# Altium schematic sheet Regulator.SchDoc(Regulator)
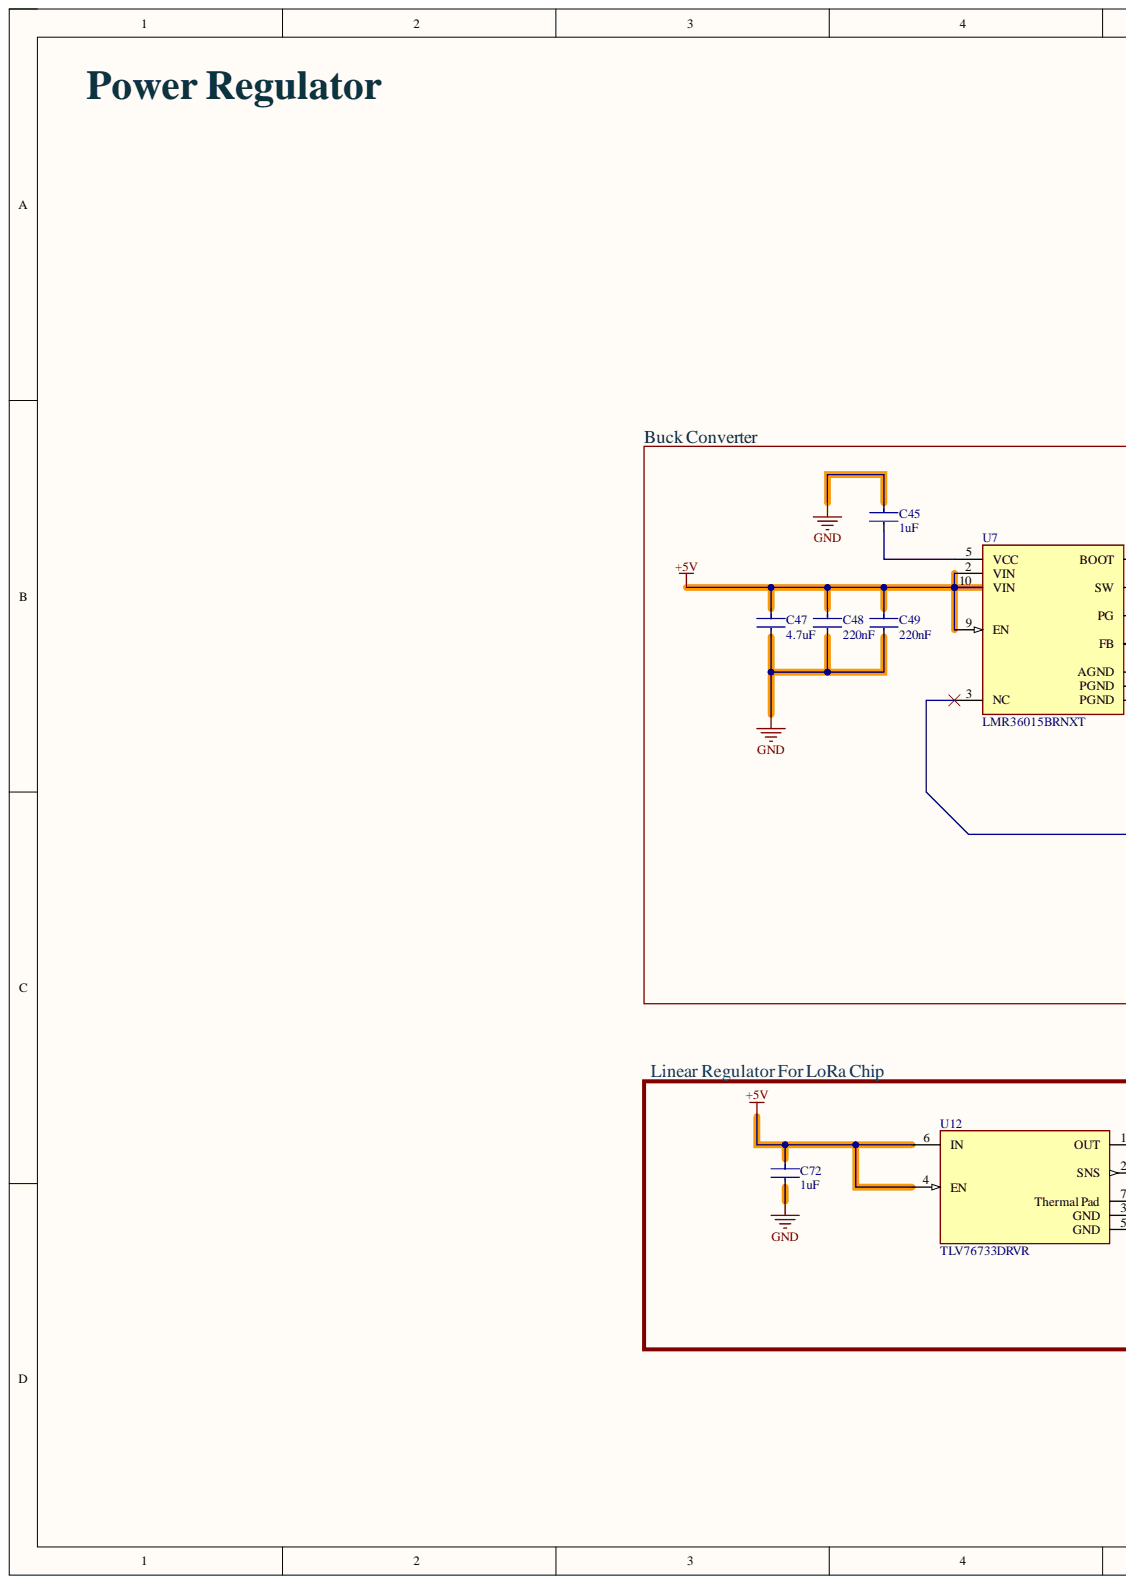
[diagram p0: Regulator.SchDoc, left]
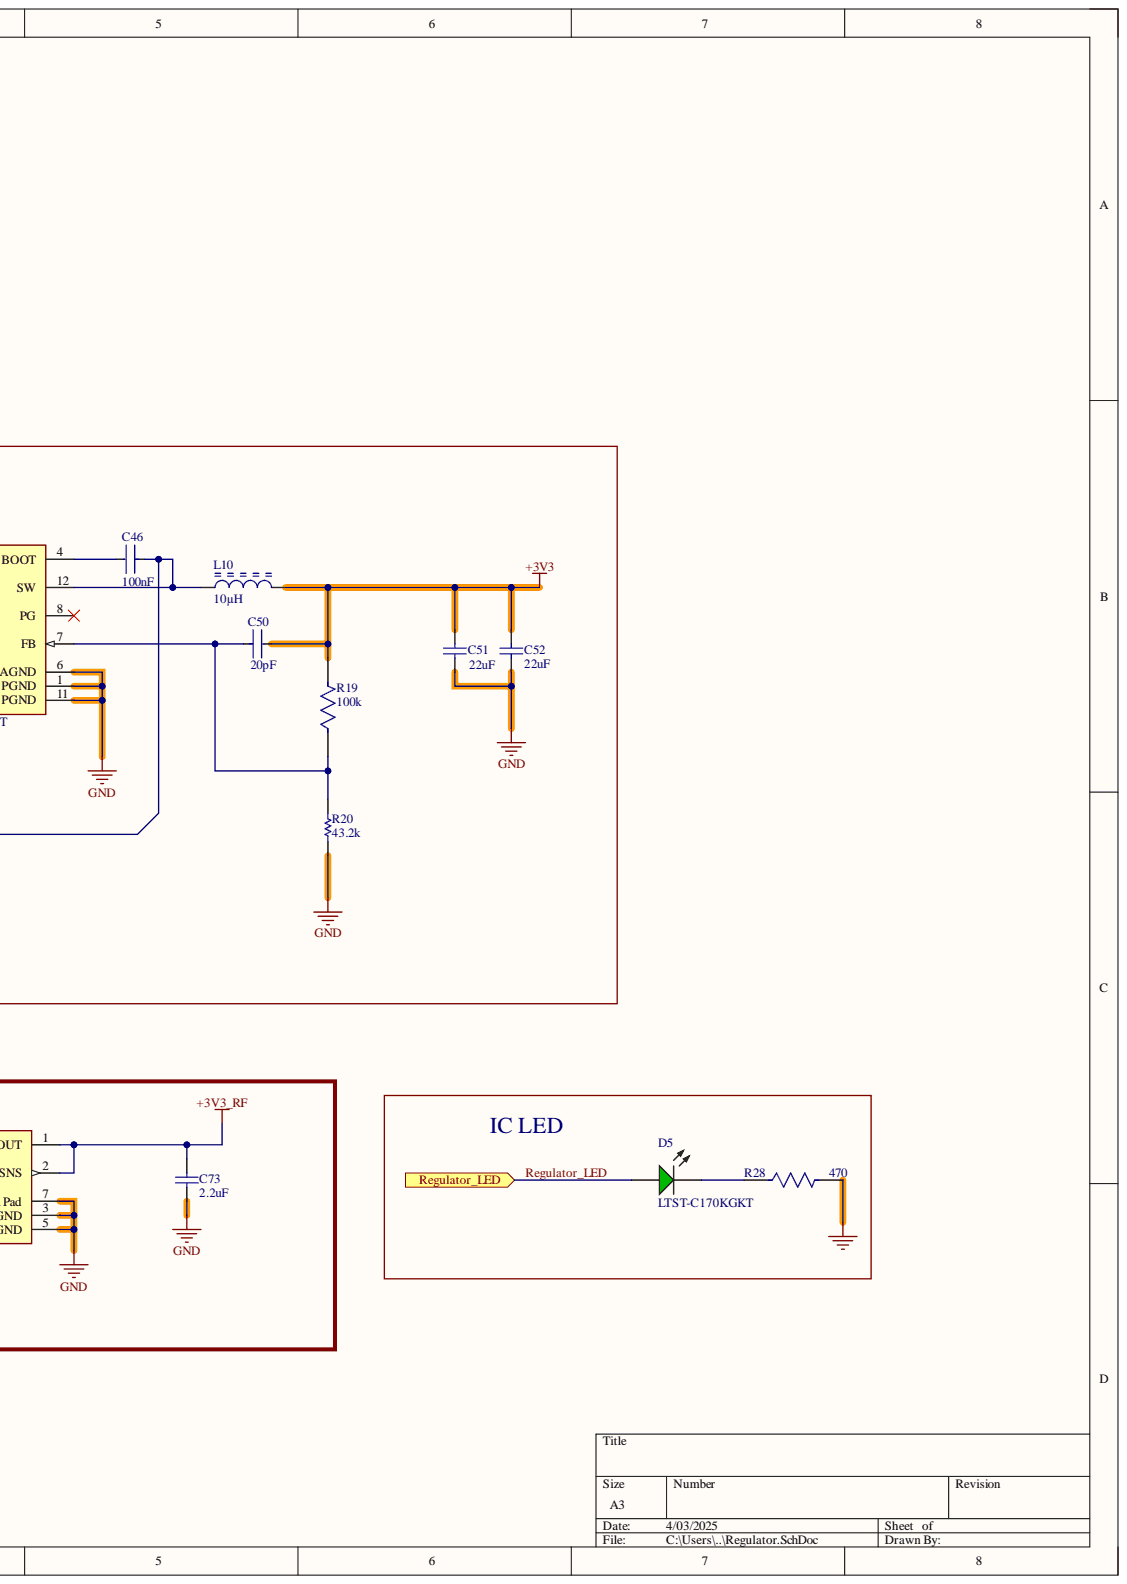
[diagram p1: Regulator.SchDoc, right]

## Parts
C45  1uF  [FP-C0603C105K4PACTU-MFG]
C46  100nF  [FP-GRM15-MFG]
C47  4.7uF  [FP-1210-L_3_3_0_4-W_2_5_0-IPC_B]
C48  220nF  [FP-GRM155-0_05-IPC_C]
C49  220nF  [FP-GRM155-0_05-IPC_C]
C50  20pF  [FP-GJM022-0_02-IPC_B]
C51  22uF  [MURA-1210_H_2.7_L]
C52  22uF  [MURA-1210_H_2.7_L]
C72  1uF  [FP-C0603C105K4PACTU-MFG]
C73  2.2uF  [CAPC3216X180X50LL20T25]
D5  LTST-C170KGKT  [LTST-LTST-C170KGKT-2_V]
L10  Inductance: 10µH  [BOUR-CM322522_V]  (hidden comment: CM322522-100KL)
R19  100k  [FP-CRCW0402-C-e3-MFG]
R20  43.2k  [RESC1005X40X25NL05T05]
R28  470  [FP-CPF0603-MFG]
U7  LMR36015BRNXT  [FP-RNX0012B-MFG]
U12  TLV76733DRVR  [FP-DRV0006A-IPC_C]

## Ports
Regulator_LED

## Nets
+3V3: C50.2 C51.2 C52.2 L10.2 R19.2
+3V3_RF: C73.2 U12.1(OUT) U12.2(SNS)
+5V: C47.1 C48.1 C49.1 C72.1 U7.2(VIN) U7.9(EN) U7.10(VIN) U12.4(EN) U12.6(IN)
GND: C45.1 C47.2 C48.2 C49.2 C51.1 C52.1 C72.2 C73.1 R20.1 R28.2 U7.1(PGND) U7.6(AGND) U7.11(PGND) U12.3(GND) U12.5(GND) U12.7(Thermal_Pad)
Regulator_LED: D5.2 ; port Regulator_LED
NetC45_2: C45.2 U7.5(VCC)
NetC46_1: C46.1 U7.4(BOOT)
NetC46_2: C46.2 L10.1 U7.3(NC) U7.12(SW)
NetC50_1: C50.1 R19.1 R20.2 U7.7(FB)
NetD5_1: D5.1 R28.1

Not connected: U7 8(PG)

## Library data of the parts
C45 C72 = CMP-2006-02279-2: CAP CER 1UF 16V X5R 0603; Dielectric Material: Ceramic; Dissipation Factor: 5%; Tolerance: 10%; Capacitance: 1uF; Case Code (Imperial): 0603; Case Code (Metric): 1608; Case/Package: 0603; Component Kind: Standard; Construction: Flat; Contact Plating: Tin; Depth: 0.8mm; Dielectric: X5R; Height: 0.8mm; Length: 1.6mm; Library Name: Altium Content Vault; Max Operating Temperature: 85°C; Min Operating Temperature: -55°C; Mount: Surface Mount; Package Quantity: 4000; Packaging: Tape and Reel; Radiation Hardening: No; Termination: SMD/SMT; Voltage: 16V; Voltage Rating: 16V; Voltage Rating (DC): 16V; Width: 0.8mm
C46 = CMP-2100-03641-2: CAP CER 0.1UF 16V X7R 0402; Manufacturer: Murata; Manufacturer Part Number: GRM155R71C104KA88D; Capacitance: 0.10μF; Material: Ceramic; Standoff Height: 0mm; Tolerance: 10%; Value: 0.10μF; Voltage Rating: 16Vdc; Category: Cap; Component Kind: Standard; ComponentLink2Description: Datasheet; ComponentLink2URL: https://search.murata.co.jp/Ceramy/image/img/A01X/G101/ENG/GRM155R71H471KA01-01A.pdf; Device Class L1: Passive Components; Device Class L2: Capacitors; Device Class L3: Ceramic Capacitors; Digikey Description: CAP CER 0.1UF 16V X7R 0402; Height: 0.55mm; Ipc Land Pattern Name: CAPC10050X219; Lead Free: yes; Library Name: Altium Content Vault; Mouser Description: 0402 0.1 uF 16V ±10 % Tolerance X7R SMT Multilayer Ceramic Capacitor; Octopart: https://octopart.com/grm155r71c104ka88d-murata-196880; Package: GRM15; RoHS: TRUE; Temperature: -55°C to +125°C; Temperature Characteristic: X7R; Temperature Range High: +125°C; Temperature Range Low: -55°C
C47 = CMP-04427-009609-1: Automotive Ceramic Capacitor, 1210, 4.7uF, 10%, X7R, 15%, 16V; Capacitance: 4.7uF; Case Code (Imperial): 1210; Case Code (Metric): 3225; Case/Package: 1210; Component Kind: Standard; Dielectric: X7R; Height: 2.29mm; Lead Free: Lead Free; Length: 0.126inch; Library Name: Altium Content Vault; Max Operating Temperature: 125°C; Min Operating Temperature: -55°C; Mount: Surface Mount; Package Quantity: 1; Packaging: Tape and Reel; Ratings: AEC-Q200; RoHS Compliant: Yes; Series: -; Termination: SMD/SMT; Tolerance: 10%; Voltage Rating: 16V; Voltage Rating (DC): 16V; Width: 2.5mm
C48 C49 = CMP-1034-02089-3: Chip Multilayer Ceramic Capacitors for General Purpose, 0402, 0.22uF, X7R, 15%, 10%, 16V; Capacitance: 220nF; Case Code (Imperial): 0402; Case Code (Metric): 1005; Case/Package: 0402; Component Kind: Standard; Depth: 0.5mm; Dielectric: X7R; Height: 0.5mm; Lead Free: Lead Free; Length: 1mm; Library Name: Altium Content Vault; Material: Ceramic; Max Operating Temperature: 125°C; Min Operating Temperature: -55°C; Mount: Surface Mount; Package Quantity: 1; Packaging: Tape and Reel; Radiation Hardening: No; REACH SVHC: No SVHC; Termination: SMD/SMT; Thickness: 0.022inch; Tolerance: 10%; Voltage: 16V; Voltage Rating: 16V; Voltage Rating (DC): 16V; Width: 0.02inch
C50 = CMP-06035-052573-1: Ceramic Capacitor 20pF ±5% 16V C0G 01005 (0402 Metric); Capacitance: 20pF; Case Code (Imperial): 1005; Case Code (Metric): 0402; Case/Package: 0402; Component Kind: Standard; Dielectric: C0G; Lead Pitch: 0.13mm; Length: 0.016inch; Library Name: Altium Content Vault; Max Operating Temperature: 125°C; Min Operating Temperature: -55°C; Mount: Surface Mount; Package Quantity: 40000; Packaging: Tape and Reel; Termination: SMD/SMT; Thickness: 0.009inch; Tolerance: 5%; Voltage Rating: 16V; Voltage Rating (DC): 16V; Width: 0.008inch
C51 C52 = CMP-2000-06361-1: Chip Monolithic Ceramic Capacitor, 22 uF, +/- 10%, -55 to 85 degC, 2-Pin SMD (1210), RoHS, Tape and Reel; Manufacturer: Murata; Capacitance: 22µF; Catalog Drawings: GRMSeries_1210_2.5; Featured Product: GA3SafetyRecognizedCapsandHi-CapMLCC; Online Catalog: GRMSeries; Other Names: 490-1882-2; Product Training Modules: High-CapMultilayerCeramicCapacitors; Temperature Coefficient: X5R; Voltage - Rated: 16V; Applications: GeneralPurpose; Category: Capacitors; Component Kind: Standard; Datasheet URL: https://datasheet.ciiva.com/26915/getdatasheetpartid-88858-26915376.pdf; Datasheet Version: 05/2014; Family: CeramicCapacitors; Features: -; Height - Seated (Max): -; Lead Spacing: -; Lead Style: -; Library Name: Altium Content Vault; Manufacturer URL: http://www.murata.com/; Mounting Technology: SurfaceMount; Mounting Type: SurfaceMount,MLCC; Operating Temperature: -55°C~85°C; Package / Case: 1210(3225Metric); Package Description: 2-Pin Surface Mount Device, Body 3.2 x 2.5 mm; Package Reference: 1210; Packaging: Tape&Reel(TR); Ratings: -; Series: GRM; Size / Dimension: 0.126"Lx0.098"W(3.20mmx2.50mm); Standard Package: 1,000; Thickness (Max): 0.106"(2.70mm); Tolerance: ±10%; Voltage Rating DC: 16V
C73 = CMP-1037-04812-2: Chip Capacitor, 2.2 uF, +/- 10%, 16 V, -55 to 125 degC, 1206 (3216 Metric), RoHS, Tape and Reel; Brand: Kemet; Capacitance - nF: 2200nF; Case Height: 1mm; Case Length: 3.2mm; Case Width: 1.6mm; Class: Class1; Dielectric: X7R; Dissipation Factor DF: 5; Temperature Coefficient / Code: +/-15%; Type: SMDMLCCX7R6.3-250VDCCommericalGrade; Voltage Rating: 16V; Capacitance: 2.2uF; Case Code - in: 1206; Case Code - mm: 3216; Component Kind: Standard; Datasheet URL: http://www.kemet.com/docfinder?Partnumber=C1206C106K8RACTU; Datasheet Version: 02/2016; Dimensions: 1.6mmWx3.2mmLx1mmH; Factory Pack Quantity: 2000; Library Name: Altium Content Vault; Manufacturer: Kemet; Manufacturer URL: http://www.kemet.com/; Maximum Operating Temperature: +125C; Minimum Operating Temperature: -55C; Mounting Technology: SurfaceMount; Operating Temperature Range: -55Cto+125C; Package / Case: 1206(3216metric); Package Description: 2-Pin Surface Mount Device, Body 3.2 x 1.6 mm; Package Reference: 1206; Packaging: Reel; Product: GeneralTypeMLCCs; Product Category: MultilayerCeramicCapacitorsMLCC-SMD/SMT; RoHS: Details; Series: C1206C; Termination Style: SMD/SMT; Tolerance: 10%; Voltage Rating DC: 16V
D5 = CMP-2000-06620-1: Ultra Bright AlInGaP Chip LED, 75 mW, -55 to 85 degC, 2-Pin SMD (0805), RoHS, Tape and Reel; Manufacturer: Lite-On; Chip Material: AlGaInP; DELETED: Compliant; Dominant Wave Length: 571nm; Emitting Color: YellowGreen; Forward Current: 30mA; Forward Voltage: 2.4V; High Brightness: Yes; Lum Intensity: 35mcd; Number of  Elements: 1; Peak Wavelength: 574nm; Power Dissipation: 75W; Power Dissipation Max: 75mW; Product Depth (mm): 1.25mm; Product Height (mm): 1.1mm; Product Length (mm): 2mm; Rad Hardened: No; Reverse Voltage: 5V; Shape Type: Rectangular; Test Current (It): 20mA; Type: Uni-Color; Viewing Angle: 130deg; Component Kind: Standard; Datasheet URL: http://optoelectronics.liteon.com/upload/download/DS22-2000-073/S_110_LTST-C170KGKT.pdf; Datasheet Version: Rev. G, 06/2005; Forward Current Max: 30mA; Lens Dimensions: 1.4x1.25x0.6mm; Library Name: Altium Content Vault; Luminous Intensity: 35mcd; Main Category: ChipLED; Manufacturer URL: http://www.us.liteon.com/; Mounting: SurfaceMount; Mounting Technology: SurfaceMount; Operating Temp Range: -55Cto85C; Operating Temperature Classification: Industrial; Package Description: 2-Pin Surface Mounted Device, Body 2 x 1.25 mm; Package Reference: LTST-C170KGKT-2; Package Type: ChipLed; Packaging: TapeandReel; Pin Count: 2
L10 = CMP-006-00005-3: Chip Inductor, 10 uH, +/- 10%, -40 to 125 degC, 1210 (3225 Metric), RoHS, Tape and Reel; Manufacturer: Bourns; Core Material: Ferrite; Current Rating: 140mA; Q Factor: 30; Resistance: 2.1Ohm; Self Resonant Frequency: 30MHz; Shielding: Unshielded; Case/Package: 1210; Component Kind: Standard; Datasheet URL: http://www.murata.com/~/media/webrenewal/support/library/catalog/products/emc/emifil/c30e.ashx?la=en-gb; Datasheet Version: Aug-14; Inductance Tolerance: 10%; Max Operating Temperature: 100°C; Min Operating Temperature: -20°C; Mounting Technology: SM; Package Description: 2-Pin Surface Mount Device, Body 3.2 x 2.5 mm; Package Quantity: 1; Package Reference: 1210; Packaging: TapeandReel; Pins: 2; RoHS Compliant: True; Tolerance: 10%; Library Name: Personal Repository
R19 = CMP-02407-010951-1: RES 100K OHM 1% 1/16W 0402; Power Rating: 62.5mW; Resistance: 100kR; Temperature Coefficient: 100ppm/°C; Case/Package: SMD/SMT; Component Kind: Standard; Contact Plating: Tin; Lead Free: Lead Free; Length: 1mm; Library Name: Altium Content Vault; Max Operating Temperature: 155°C; Min Operating Temperature: -55°C; Mount: Surface Mount; Resistor Type: Thick Film; RoHS Compliant: Yes; Termination: Wraparound; Tolerance: 1%
R20 = CMP-1011-00695-2: Manufacturer: Vishay Dale; Part Number: CRCW040243K2FKED; Component Kind: Standard; Library Name: Altium Content Vault
R28 = CMP-03211-004765-1: RES SMD 470Ω 0.1% 1/16W 0603    CPF0603B470RE; Manufacturer: TE Connectivity; Power Rating: 0.063W; Temperature Coefficient: 25ppm/°C; Voltage Rating: 50V; Automotive: No; Category: Res; Component Kind: Standard; ComponentLink2Description: Datasheet; ComponentLink2URL: https://www.te.com/commerce/DocumentDelivery/DDEController?Action=showdoc&DocId=Data+Sheet%7F1773200%7FM%7Fpdf%7FEnglish%7FENG_DS_1773200_M.pdf%7F3-1676481-2; Composition: Thin Film; Device Class L1: Passive Components; Device Class L2: Resistors; Device Class L3: Chip SMD Resistors; Height: 0.55mm; Ipc Land Pattern Name: RESC15580X45; Lead Free: Yes; Library Name: Altium Content Vault; Manufacturer Part Number: CPF0603B470RE; Octopart: https://octopart.com/cpf0603b470re-te+connectivity-23376607; octopart_url: https://octopart.com/cpf0603b470re-te+connectivity-23376607; Package: CPF0603; packaging: 5k Reel; Resistance: 470Ω; RoHS: TRUE; Series: CPF; Temperature: -55°C to +155°C; Temperature Range High: +155°C; Temperature Range Low: -55°C; Tolerance: 0.1%; Value: 470Ω
U7 = CMP-04918-000354-1: IC REGULATOR BUCK; Component Kind: Standard; Library Name: Altium Content Vault
U12 = CMP-04917-000203-1: VOLTAGE COMPARATOR; Component Kind: Standard; Library Name: Altium Content Vault
